# Altium schematic sheet DAC Board TOP.SchDoc
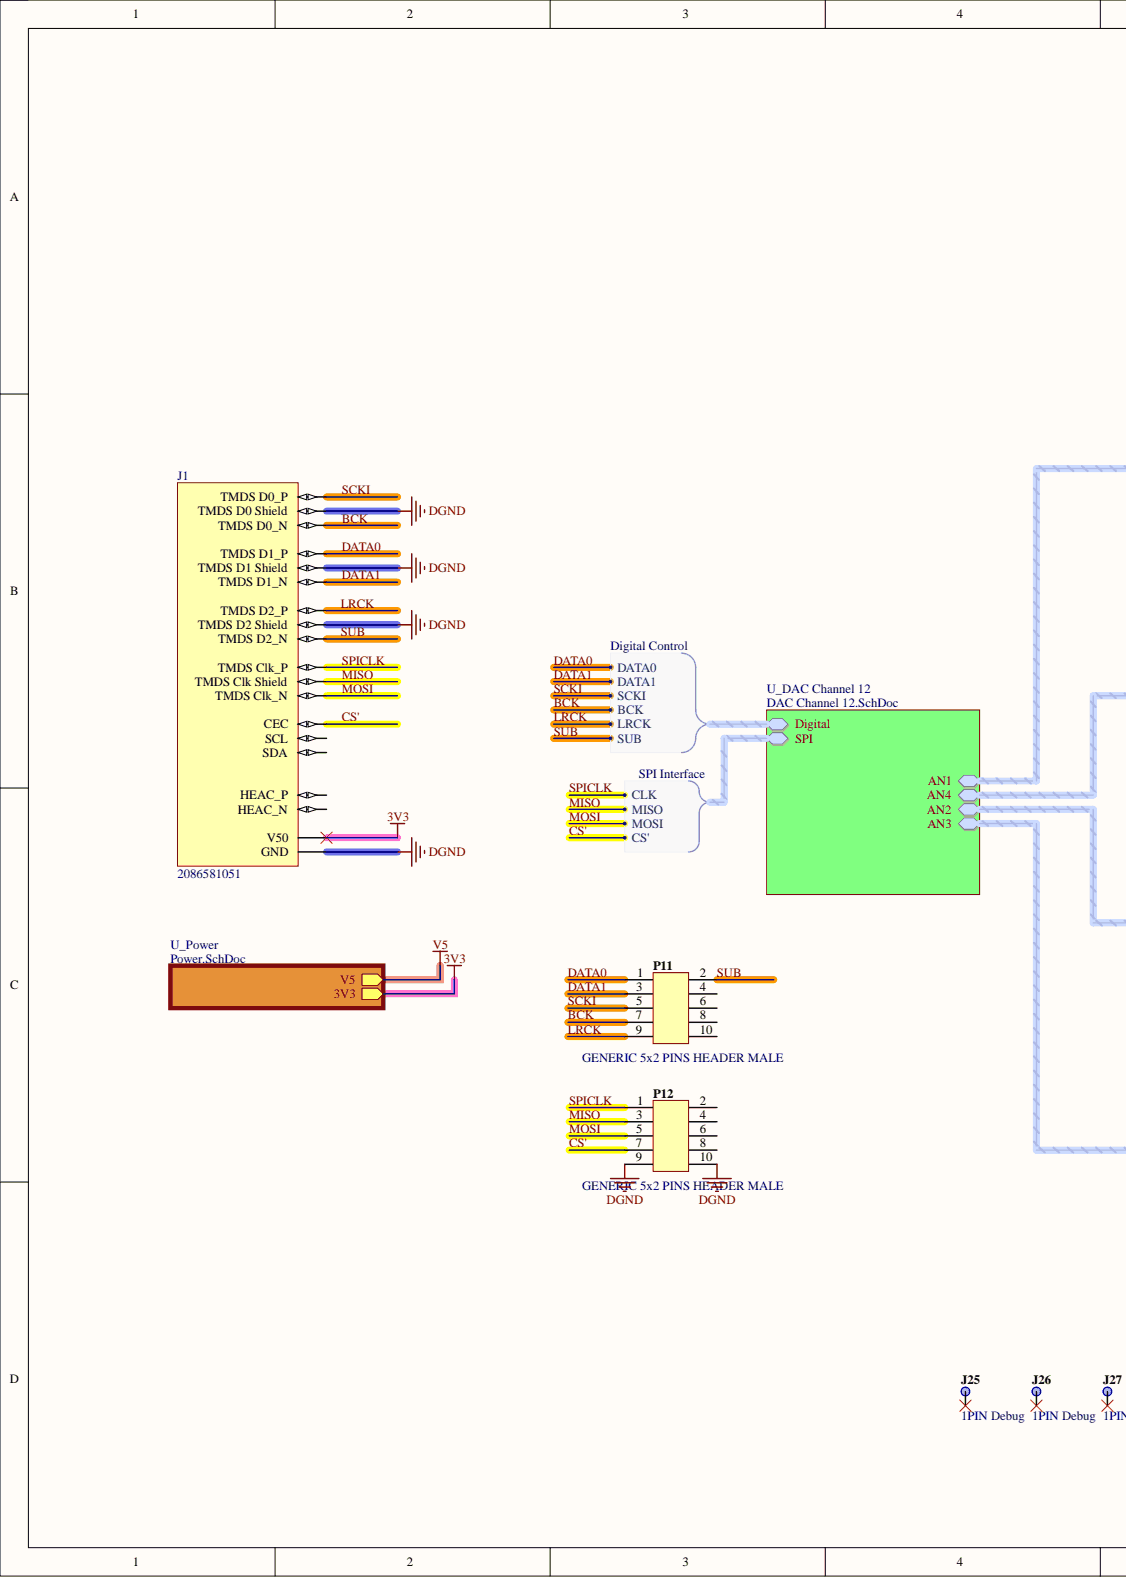
[diagram p0: DAC Board TOP.SchDoc, left]
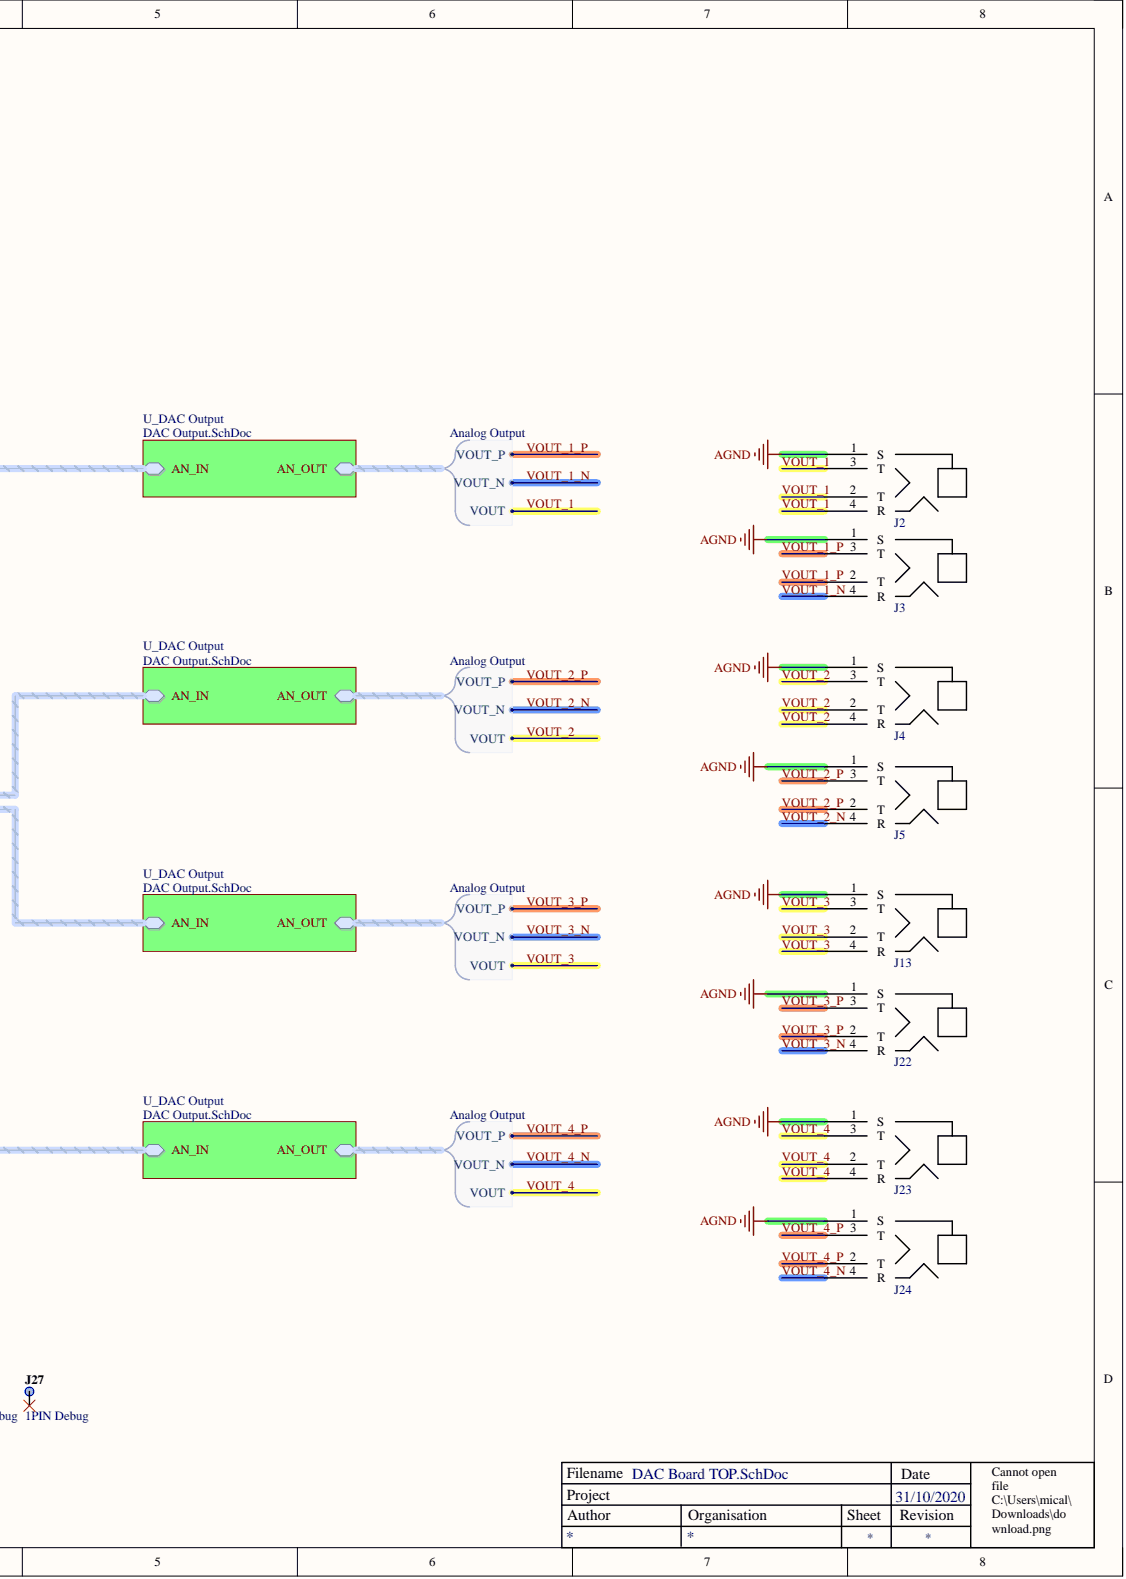
[diagram p1: DAC Board TOP.SchDoc, right]

## Parts
J1  2086581051  [2086581051]
J2  SJ1-2503A  [SJ1-2503A-Footprint-1]  (value not drawn)
J3  SJ1-2503A  [SJ1-2503A-Footprint-1]  (value not drawn)
J4  SJ1-2503A  [SJ1-2503A-Footprint-1]  (value not drawn)
J5  SJ1-2503A  [SJ1-2503A-Footprint-1]  (value not drawn)
J13  SJ1-2503A  [SJ1-2503A-Footprint-1]  (value not drawn)
J22  SJ1-2503A  [SJ1-2503A-Footprint-1]  (value not drawn)
J23  SJ1-2503A  [SJ1-2503A-Footprint-1]  (value not drawn)
J24  SJ1-2503A  [SJ1-2503A-Footprint-1]  (value not drawn)
J25  1PIN Debug  [1 Pin Debug Port 0.9mm]
J26  1PIN Debug  [1 Pin Debug Port 0.9mm]
J27  1PIN Debug  [1 Pin Debug Port 0.9mm]
P11  GENERIC 5x2 PINS HEADER MALE  [GENERIC 5PIN 2ROW HEADER 0]
P12  GENERIC 5x2 PINS HEADER MALE  [GENERIC 5PIN 2ROW HEADER 0]

## Nets
3V3: J1.18(V50)
AGND: J2.1(S) J3.1(S) J4.1(S) J5.1(S) J13.1(S) J22.1(S) J23.1(S) J24.1(S)
DGND: J1.2(TMDS_D2_Shield) J1.5(TMDS_D1_Shield) J1.8(TMDS_D0_Shield) J1.17(GND) P12.9 P12.10
BCK: J1.9(TMDS_D0_N) P11.7
CS': J1.13(CEC) P12.7
DATA0: J1.4(TMDS_D1_P) P11.1
DATA1: J1.6(TMDS_D1_N) P11.3
LRCK: J1.1(TMDS_D2_P) P11.9
MISO: J1.11(TMDS_Clk_Shield) P12.3
MOSI: J1.12(TMDS_Clk_N) P12.5
SCKI: J1.7(TMDS_D0_P) P11.5
SPICLK: J1.10(TMDS_Clk_P) P12.1
SUB: J1.3(TMDS_D2_N) P11.2
VOUT_1: J2.2(T) J2.3(T) J2.4(R)
VOUT_1_N: J3.4(R)
VOUT_1_P: J3.2(T) J3.3(T)
VOUT_2: J4.2(T) J4.3(T) J4.4(R)
VOUT_2_N: J5.4(R)
VOUT_2_P: J5.2(T) J5.3(T)
VOUT_3: J13.2(T) J13.3(T) J13.4(R)
VOUT_3_N: J22.4(R)
VOUT_3_P: J22.2(T) J22.3(T)
VOUT_4: J23.2(T) J23.3(T) J23.4(R)
VOUT_4_N: J24.4(R)
VOUT_4_P: J24.2(T) J24.3(T)
NetJ1_14: J1.14(HEAC_P)
NetJ1_15: J1.15(SCL)
NetJ1_16: J1.16(SDA)
NetJ1_19: J1.19(HEAC_N)
NetJ25_1: J25.1
NetJ26_1: J26.1
NetJ27_1: J27.1
NetP11_4: P11.4
NetP11_6: P11.6
NetP11_8: P11.8
NetP11_10: P11.10
NetP12_2: P12.2
NetP12_4: P12.4
NetP12_6: P12.6
NetP12_8: P12.8
N00001:
N00002:
N00003:
N00004:
N00005:
N00006:
N00007:
N00008:
V5:

## Library data of the parts
J1 = 2086581051-2: CONN FEM SMD 19 90Deg HDMI; Manufacturer: Molex; Case/Package: 4 PIN; Component Kind: Standard; Design Item ID: 2086581051; Source: Connector Library.SchLib; Status: Active; Supplier 1: Mouser; Supplier Part Number 1: Mouser; Type: HYBRID; Library Name: University of Malta - MEMENTO
J2-J5 J13 J22-J24 = SJ1-2503A-1: CONN JACK STEREO 2.5MM R/A; Body Material: Brass; Current Rating: 1A; Gender: Female; Number of Conductors: 3; Number of Ports: 1; Shielding: Unshielded; Color: Black; Component Kind: Standard; Connector Type: Jack; Contact Plating: Tin; Diameter: 2.5mm; Lead Free: Lead Free; Mount: Through Hole; Orientation: Horizontal; Packaging: Bulk; Termination: Solder; Library Name: University of Malta - MEMENTO
J25-J27 = 1PIN Debug-2: CONN MAL TH 1 0Deg HEADER; Case/Package: 1 PIN; Component Kind: Standard; Design Item ID: 1PIN Debug; Source: Connector Library.SchLib; Status: NC; Type: N/A; Library Name: University of Malta - MEMENTO
P11 P12 = GENERIC 5x2 PINS HEADER MALE-2: CONN MAL TH 5x2 0Deg HEADER; Bin: B3-J5; Case/Package: 2 PIN; Component Kind: Standard; Design Item ID: GENERIC 5x2 PINS HEADER MALE; Manufacturer: Generic; Source: Connector Library.SchLib; Status: Active; Type: TH; Library Name: University of Malta - MEMENTO
